# Altium schematic sheet 08_PLC.SchDoc(08_PLC)
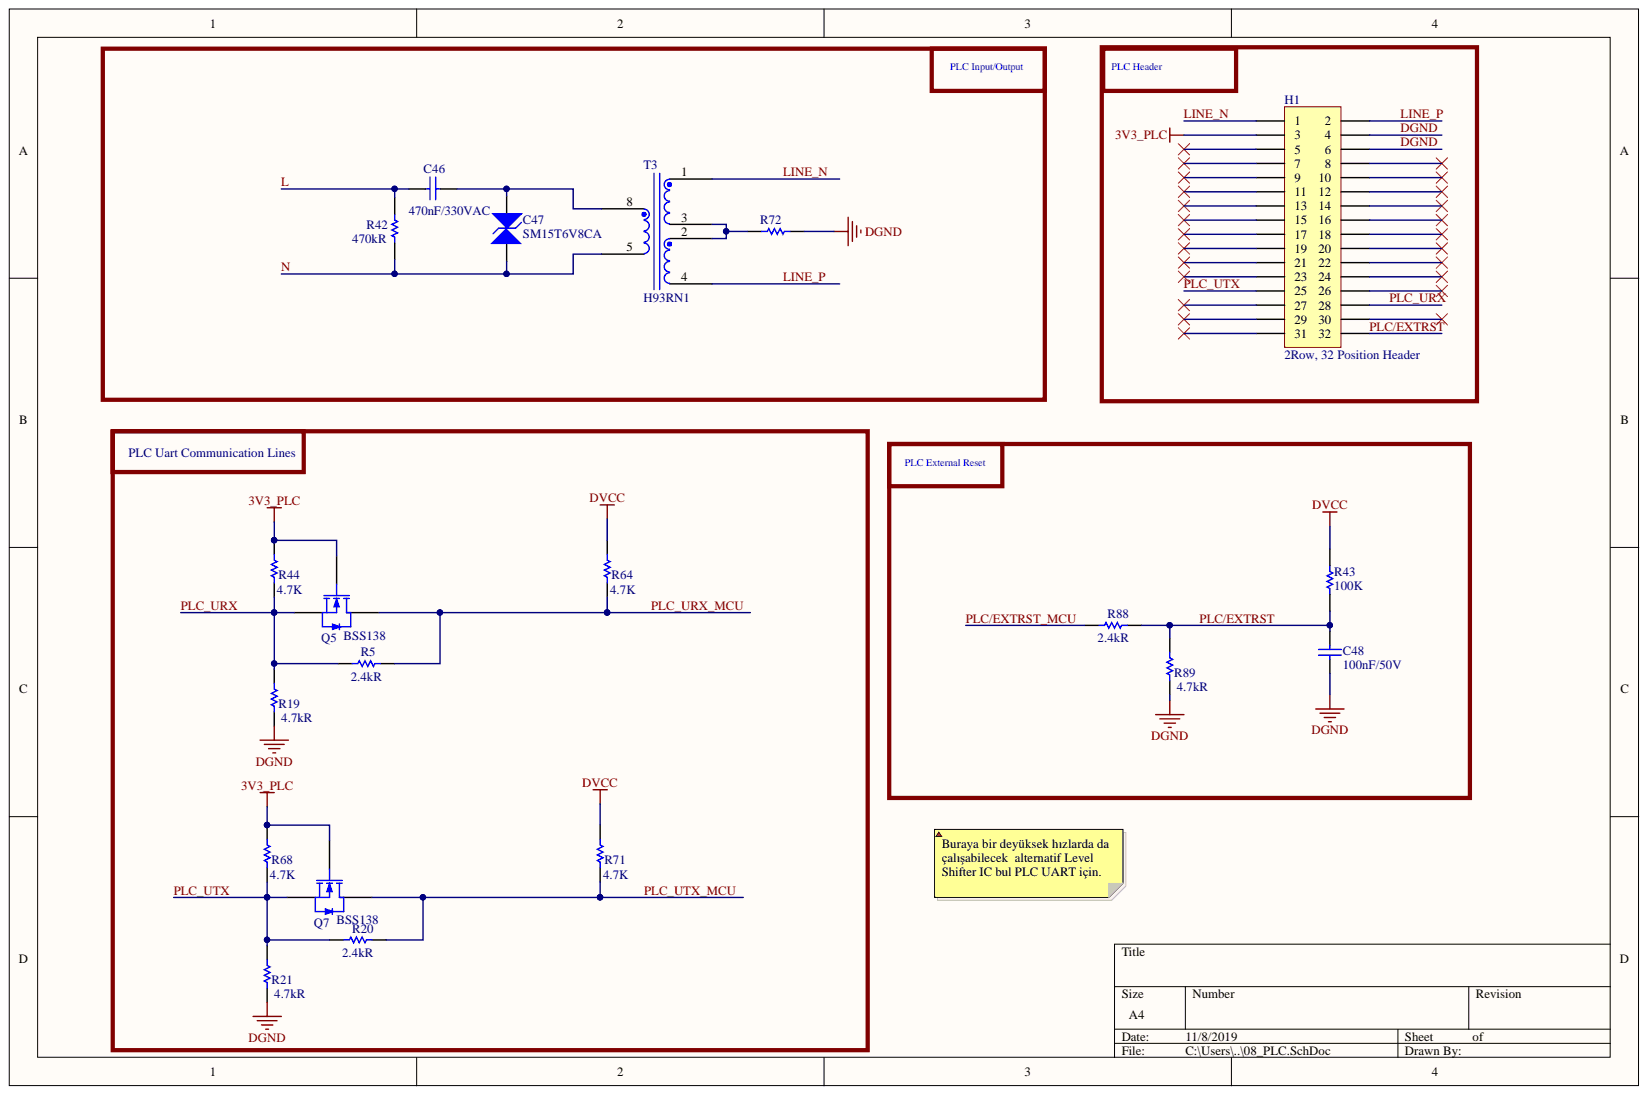
[diagram p0: 08_PLC.SchDoc]

## Parts
C46  470nF/330VAC  [CAP_THT_MKP_P=22.5MM_AXIAL_RADIAL _10.5x18.5x26.5]
C47  SM15T6V8CA  [SMC(DO_214AB)]
C48  100nF  [CAP_0603_V1]
H1  2Row, 32 Position Header  [IT-900]
Q5  BSS138  [SOT23(TO-236)]
Q7  BSS138  [SOT23(TO-236)]
R5  Resistance: 2.4kR  [RES_0603_V1]  (hidden comment: 0R)
R19  Resistance: 4.7kR  [RES_0603_V1]  (hidden comment: RES_SMD)
R20  Resistance: 2.4kR  [RES_0603_V1]  (hidden comment: 0R)
R21  Resistance: 4.7kR  [RES_0603_V1]  (hidden comment: RES_SMD)
R42  Resistance: 470kR  [RES_2512_V1]  (hidden comment: CRCW2512470KJNEG)
R43  100K  [RES_0603_V1]
R44  004_Value: 4.7K  [RES_0603_V1]  (hidden comment: RES_SMD)
R64  004_Value: 4.7K  [RES_0603_V1]  (hidden comment: RES_SMD)
R68  004_Value: 4.7K  [RES_0603_V1]  (hidden comment: RES_SMD)
R71  004_Value: 4.7K  [RES_0603_V1]  (hidden comment: RES_SMD)
R72  RES_SMD  [RES_1206_V1]  (value not drawn)
R88  Resistance: 2.4kR  [RES_0603_V1]  (hidden comment: 0R)
R89  Resistance: 4.7kR  [RES_0603_V1]  (hidden comment: RES_SMD)
T3  H93RN1  [H93RN1]

## Nets
3V3_PLC: H1.3 Q5.1 Q7.1 R44.2 R68.2
DVCC: R43.2 R64.2 R71.2
DGND: C48.1 H1.4 H1.6 R19.1 R21.1 R72.2 R89.1
L: C46.1 R42.2
LINE_N: H1.1 T3.1
LINE_P: H1.2 T3.4
N: C47.2 R42.1 T3.5
PLC/EXTRST: C48.2 H1.32 R43.1 R88.2 R89.2
PLC/EXTRST_MCU: R88.1
PLC_URX: H1.28 Q5.2 R5.1 R19.2 R44.1
PLC_URX_MCU: Q5.3 R5.2 R64.1
PLC_UTX: H1.25 Q7.2 R20.1 R21.2 R68.1
PLC_UTX_MCU: Q7.3 R20.2 R71.1
NetC46_2: C46.2 C47.1 T3.8
NetR72_1: R72.1 T3.2 T3.3

Not connected: H1 5 7 8 9 10 11 12 13 14 15 16 17 18 19 20 21 22 23 24 26 27 29 30 31

## Library data of the parts
C46 = B32913A3474K: 0.47µF Film Capacitor 330V 760V Polypropylene (PP), Metallized Radial 1.043" L x 0.413" W (26.50mm x 10.50mm); 016_Manufacturer-1: TDK EPCOS; Tolerance: 10%; Parameter Name: Bulk; Lead Diameter: 0.8mm; Capacitance: 470nF; Width: 0.413inch; Voltage Rating (DC): 760V; Voltage Rating (AC): 330V; Termination: Radial; Supplier Part Number 1: B32913A3474K; Supplier 1: Perfect Parts; Stock 1: 0; Package Quantity: 540; Mount: Through Hole; Min Operating Temperature: -40°C; Max Operating Temperature: 110°C; Library Name: ElectricMeter.SchLib; Length: 1.043inch; Lead Pitch: 22.5mm; Height - Seated (Max): 0.728inch; Dielectric Material: PP; Dielectric: PP; Depth: 10.5mm; ComponentLink1URL: http://www.epcos.com/inf/20/20/db/fc_2009/X1_B32911_916.pdf?src-supplier=Avnet; ComponentLink1Description: http://www.epcos.com/inf/20/20/db/fc_2009/X1_B32911_916.pdf?src-supplier=Avnet; Component Kind: Standard; Case/Package: Radial; 017_Manufacturer-1 PN: B32913A3474K
C47 = SM15T6V8CA: TVS DIODE 5.8VWM 13.4VC SMC; 048_Manufacturer-2 PN: SMCJ1.5KE6.8CA-TP; 047_Manufacturer-2: MCC; 016_Manufacturer-1: STMicroelectronics; Voltage Rating (DC): 6.8V; Test Current: 10mA; Reverse Standoff Voltage: 5.8V; Reverse Breakdown Voltage: 6.45V; Power Line Protection: No; Power Dissipation: 1.5kW; Polarity: Bidirectional; Peak Pulse Current: 746A; Parameter Name: Tape and Reel; Number of Elements: 1; Max Surge Current: 143A; Max Reverse Leakage Current: 500uA; Max Breakdown Voltage: 7.14V; Element Configuration: Single; Clamping Voltage: 13.4V; Capacitance: 9.5nF; 004_Value: Zener; Width: 6.25mm; Termination: SMD/SMT; Supplier Part Number 1: 4864892; Supplier 1: RSComponents; Stock 1: 0; REACH SVHC: No SVHC; Radiation Hardening: No; Peak Pulse Power: 1.5kW; Package Quantity: 1; Operating Supply Voltage: 5.8V; Number of Pins: 2; Number of Bidirectional Channels: 1; Mount: Surface Mount; Min Operating Temperature: -55°C; Min Breakdown Voltage: 6.45V; Max Power Dissipation: 1.5kW; Max Operating Temperature: 150°C; Library Name: ElectricMeter.SchLib; Length: 7.15mm; Leakage Current: 500uA; Lead Free: Lead Free; Height: 2.45mm; Direction: Bidirectional; ComponentLink5URL: http://datasheet.iiic.cc/stmicroelectronics/SM15T6V8CA.pdf?src-supplier=IIIC; ComponentLink5Description: http://datasheet.iiic.cc/stmicroelectronics/SM15T6V8CA.pdf?src-supplier=IIIC; ComponentLink4URL: http://www.st.com/st-web-ui/static/active/en/resource/technical/document/datasheet/CD00000724.pdf?src-supplier=Future+Electronics; ComponentLink4Description: http://www.st.com/st-web-ui/static/active/en/resource/technical/document/datasheet/CD00000724.pdf?src-supplier=Future+Electronics; ComponentLink3URL: https://www.distrelec.biz/Web/Downloads/_t/ds/sm15t_eng_tds.pdf?mime=application%2Fpdf&src-supplier=Distrelec; ComponentLink3Description: https://www.distrelec.biz/Web/Downloads/_t/ds/sm15t_eng_tds.pdf?mime=application%2Fpdf&src-supplier=Distrelec; ComponentLink2URL: http://www.farnell.com/datasheets/1670952.pdf?src-supplier=Element14; ComponentLink2Description: http://www.farnell.com/datasheets/1670952.pdf?src-supplier=Element14; ComponentLink1URL: http://www.st.com/content/ccc/resource/technical/document/datasheet/a5/63/bb/0d/f0/b8/44/65/CD00000724.pdf/files/CD00000724.pdf/jcr:content/translations/en.CD00000724.pdf?src-supplier=Digi-Key; ComponentLink1Description: http://www.st.com/content/ccc/resource/technical/document/datasheet/a5/63/bb/0d/f0/b8/44/65/CD00000724.pdf/files/CD00000724.pdf/jcr:content/translations/en.CD00000724.pdf?src-supplier=Digi-Key; Component Kind: Standard; Case/Package: SMC; Breakdown Voltage: 6.45V; 017_Manufacturer-1 PN: SM15T6V8CA
C48 = 100nF/50V: MLCC, 100nF, 50V, X7R, 0603, %10; 017_Manufacturer-1 PN: CL10B104KB8NNNC; 016_Manufacturer-1: Samsung; 008_Package: C0603; 007_Status: MP; 006_SMT/THT: SMT; 005_Process: SMD_Mounter; 001_Designation: Capacitor; Library Name: BM.10.LC02.SCHLIB; Component Kind: Standard; 004_Value: 100nF/50V
H1 = HEADER2x16_MALE_2MM: 2mm pitch, Dielectric Voltage 500VAC, temperature -40 C 105 C Insulation Resistance 1000 Mohm; Library Name: ElectricMeter.SchLib; ComponentLink1URL: http://www.amtek-co.com.tw/upload/products/product_file/2015PDF-2-47_5PS2XXAXX-X-3.pdf; ComponentLink1Description: Manufacturer Datasheet; Component Kind: Standard
Q5 Q7 = BSS138: MOSFET N-CH 50V 220MA SOT-23; 016_Manufacturer-1: TI National Semiconductor; Supplier Part Number 1: BSS138; Supplier 1: Quest; Stock 1: 0; Library Name: ElectricMeter.SchLib; Component Kind: Standard; 017_Manufacturer-1 PN: BSS138; 001_Description: MOSFET N-CH 50V 220MA SOT-23
R5 R20 R88 = RES_SMD: 017_Manufacturer-1 PN: RC0603FR-072K4L; 016_Manufacturer-1: Yageo; Working Voltage: 75V; Voltage Rating (DC): 50V; Tolerance: 1%; Temperature Coefficient: 100ppm/°C; Power Rating: 100mW; Parameter Name: Tape and Reel; 001_Description: RES SMD 2.4K OHM 1% 1/10W 0603; Width: 0.031inch; Voltage Rating: 50V; Termination: SMD/SMT; Supplier Part Number 1: 505-0555; Supplier 1: RSComponents; Stock 1: 0; REACH SVHC: No SVHC; Package Quantity: 1; Number of Terminations: 2; Number of Pins: 2; Min Operating Temperature: -55°C; Military Standard: Not; Max Power Dissipation: 100mW; Max Operating Temperature: 155°C; Library Name: ElectricMeter.SchLib; Length: 1.6mm; Lead Free: Lead Free; Height: 0.45mm; Features: Moisture Resistant; Depth: 0.8mm; Composition: Thick Film; ComponentLink4URL: http://www.mouser.com/catalog/specsheets/RC0603.pdf?src-supplier=Mouser; ComponentLink4Description: http://www.mouser.com/catalog/specsheets/RC0603.pdf?src-supplier=Mouser; ComponentLink3URL: http://www.yageo.com/documents/recent/PYu-RC_Group_51_RoHS_L_10.pdf?src-supplier=Digi-Key; ComponentLink3Description: http://www.yageo.com/documents/recent/PYu-RC_Group_51_RoHS_L_10.pdf?src-supplier=Digi-Key; ComponentLink2URL: https://www.verical.com/server-webapp/html/getDatasheet?partID=327915&src-supplier=Verical; ComponentLink2Description: https://www.verical.com/server-webapp/html/getDatasheet?partID=327915&src-supplier=Verical; ComponentLink1URL: http://www.yageo.com/documents/recent/PYu-RC_Group_51_RoHS_L_9.pdf?src-supplier=Digi-Key; ComponentLink1Description: http://www.yageo.com/documents/recent/PYu-RC_Group_51_RoHS_L_9.pdf?src-supplier=Digi-Key; Component Kind: Standard; Case Code (Metric): 1608; Case Code (Imperial): 0603; Case/Package: 0603
R19 R21 R89 = RES_SMD: 017_Manufacturer-1 PN: RC0603FR-074K7L; 016_Manufacturer-1: Yageo; Voltage Rating (DC): 50V; Tolerance: 1%; Temperature Coefficient: 100ppm/°C; Power Rating: 100mW; Parameter Name: Tape and Reel; 001_Description: RES SMD 4.7K OHM 1% 1/10W 0603; Width: 0.8mm; Voltage Rating: 50V; Termination: SMD/SMT; Supplier Part Number 1: 98K7411; Supplier 1: Newark; Stock 1: 0; REACH SVHC: No SVHC; Number of Pins: 2; Min Operating Temperature: -55°C; Military Standard: Not; Max Operating Temperature: 155°C; Library Name: ElectricMeter.SchLib; Length: 1.6mm; Lead Pitch: 0.3mm; Lead Free: Lead Free; Height: 0.45mm; Depth: 0.8mm; Composition: Thick Film; ComponentLink4URL: http://www.farnell.com/datasheets/1868787.pdf?src-supplier=Element14; ComponentLink4Description: http://www.farnell.com/datasheets/1868787.pdf?src-supplier=Element14; ComponentLink3URL: http://www.farnell.com/datasheets/38590.pdf?src-supplier=Element14; ComponentLink3Description: http://www.farnell.com/datasheets/38590.pdf?src-supplier=Element14; ComponentLink2URL: http://www.farnell.com/datasheets/2167052.pdf?src-supplier=Element14; ComponentLink2Description: http://www.farnell.com/datasheets/2167052.pdf?src-supplier=Element14; ComponentLink1URL: https://www.verical.com/server-webapp/html/getDatasheet?partID=422754&src-supplier=Verical; ComponentLink1Description: https://www.verical.com/server-webapp/html/getDatasheet?partID=422754&src-supplier=Verical; Component Kind: Standard; Case Code (Metric): 1608; Case Code (Imperial): 0603; Case/Package: 0603
R42 = CRCW2512470KJNEG: RES SMD 470K OHM 5% 1W 2512; 016_Manufacturer-1: Vishay Dale; Voltage Rating (DC): 500V; Temperature Coefficient: 200ppm/°C; Parameter Name: Tape and Reel; Width: 3.15mm; Weight: 0.001429oz; Voltage Rating: 500V; Tolerance: 5%; Termination: SMD/SMT; Supplier Part Number 1: CRCW2512470KJNEG; Supplier 1: Perfect Parts; Stock 1: 0; REACH SVHC: Unknown; Radiation Hardening: No; Power Rating: 1W; Package Quantity: 1; Number of Terminations: 2; Number of Pins: 2; Min Operating Temperature: -55°C; Military Standard: Not; Max Power Dissipation: 1W; Max Operating Temperature: 155°C; Library Name: ElectricMeter.SchLib; Length: 6.3mm; Lead Free: Lead Free; Height: 0.028inch; Features: Automotive AEC-Q200; Depth: 3.15mm; Contact Plating: Tin; Composition: Thick Film; ComponentLink3URL: http://www.vishay.com/docs/20035/dcrcwe3.pdf?src-supplier=Digi-Key; ComponentLink3Description: http://www.vishay.com/docs/20035/dcrcwe3.pdf?src-supplier=Digi-Key; ComponentLink2URL: http://www.vishay.com/docs/20035/dcrcwe3.pdf?src-supplier=Avnet; ComponentLink2Description: http://www.vishay.com/docs/20035/dcrcwe3.pdf?src-supplier=Avnet; ComponentLink1URL: http://www.mouser.com/ds/2/427/dcrcwe3-109170.pdf?src-supplier=Mouser; ComponentLink1Description: http://www.mouser.com/ds/2/427/dcrcwe3-109170.pdf?src-supplier=Mouser; Component Kind: Standard; Case Code (Metric): 6432; Case Code (Imperial): 2512; Case/Package: 2512; 017_Manufacturer-1 PN: CRCW2512470KJNEG; 001_Description: RES SMD 470K OHM 5% 1W 2512
R43 = RC0603FR-07100KL: RES SMD 100K OHM 1% 1/10W 0603; 016_Manufacturer-1: Yageo; Working Voltage: 75V; Voltage Rating (DC): 50V; Temperature Coefficient: 100ppm/°C; Resistance: 100kR; Power Rating: 100mW; Parameter Name: Tape and Reel; Width: 0.8mm; Voltage Rating: 50V; Tolerance: 1%; Termination: SMD/SMT; Supplier Part Number 1: 504-8940; Supplier 1: RSComponents; Stock 1: 0; REACH SVHC: No SVHC; Number of Terminations: 2; Number of Pins: 2; Min Operating Temperature: -55°C; Military Standard: Not; Max Operating Temperature: 155°C; Library Name: ElectricMeter.SchLib; Length: 1.6mm; Lead Free: Lead Free; Height: 0.45mm; Depth: 0.8mm; Composition: Thick Film; ComponentLink5URL: http://www.farnell.com/datasheets/443971.pdf?src-supplier=Element14; ComponentLink5Description: http://www.farnell.com/datasheets/443971.pdf?src-supplier=Element14; ComponentLink4URL: http://documents.rs-components.com/techlib://itc_doclist_GB.htm?stockno=5048940&src-supplier=RSComponents; ComponentLink4Description: http://documents.rs-components.com/techlib://itc_doclist_GB.htm?stockno=5048940&src-supplier=RSComponents; ComponentLink3URL: http://www.farnell.com/datasheets/1738695.pdf?src-supplier=Element14; ComponentLink3Description: http://www.farnell.com/datasheets/1738695.pdf?src-supplier=Element14; ComponentLink2URL: http://www.farnell.com/datasheets/1868787.pdf?src-supplier=Element14; ComponentLink2Description: http://www.farnell.com/datasheets/1868787.pdf?src-supplier=Element14; ComponentLink1URL: http://www.farnell.com/datasheets/2167052.pdf?src-supplier=Element14; ComponentLink1Description: http://www.farnell.com/datasheets/2167052.pdf?src-supplier=Element14; Component Kind: Standard; Case Code (Metric): 1608; Case Code (Imperial): 0603; Case/Package: 0603; 017_Manufacturer-1 PN: RC0603FR-07100KL
R44 R64 R68 R71 = RES_SMD: 017_Manufacturer-1 PN: 0603SAF4701T5E; 016_Manufacturer-1: Royal Electronic Factory; Tolerance: 1%; 001_Description: Resistor: thick film; SMD; 0603; 4.7kO; 0.1W; ±1%; -55÷125°C; Supplier Part Number 2: SMD0603-2K7; Supplier Part Number 1: 0603SAF4701T5E; Supplier 2: TME; Supplier 1: TME; Stock 2: 15900; Stock 1: 187000; Pricing 2: 100=0.01075, 1000=0.00262, 5000=0.00122, 15000=0.00103, 50000=0.00094 (EUR); Pricing 1: 5000=0.00301, 50000=0.00215, 200000=0.002 (USD); Library Name: ElectricMeter.SchLib; Component Kind: Standard; Case/Package: 0603
T3 = H93RN1: Coupling Transformer; 016_Manufacturer-1: Hitachi; Library Name: ElectricMeter.SchLib; Component Kind: Standard; 017_Manufacturer-1 PN: H93RN1; 004_Value: Coupling Transformer
R72 = RES_SMD: Component Kind: Standard; Library Name: BM_COMM_180705.IntLib
